# Altium schematic sheet MCU.SchDoc(MCU)
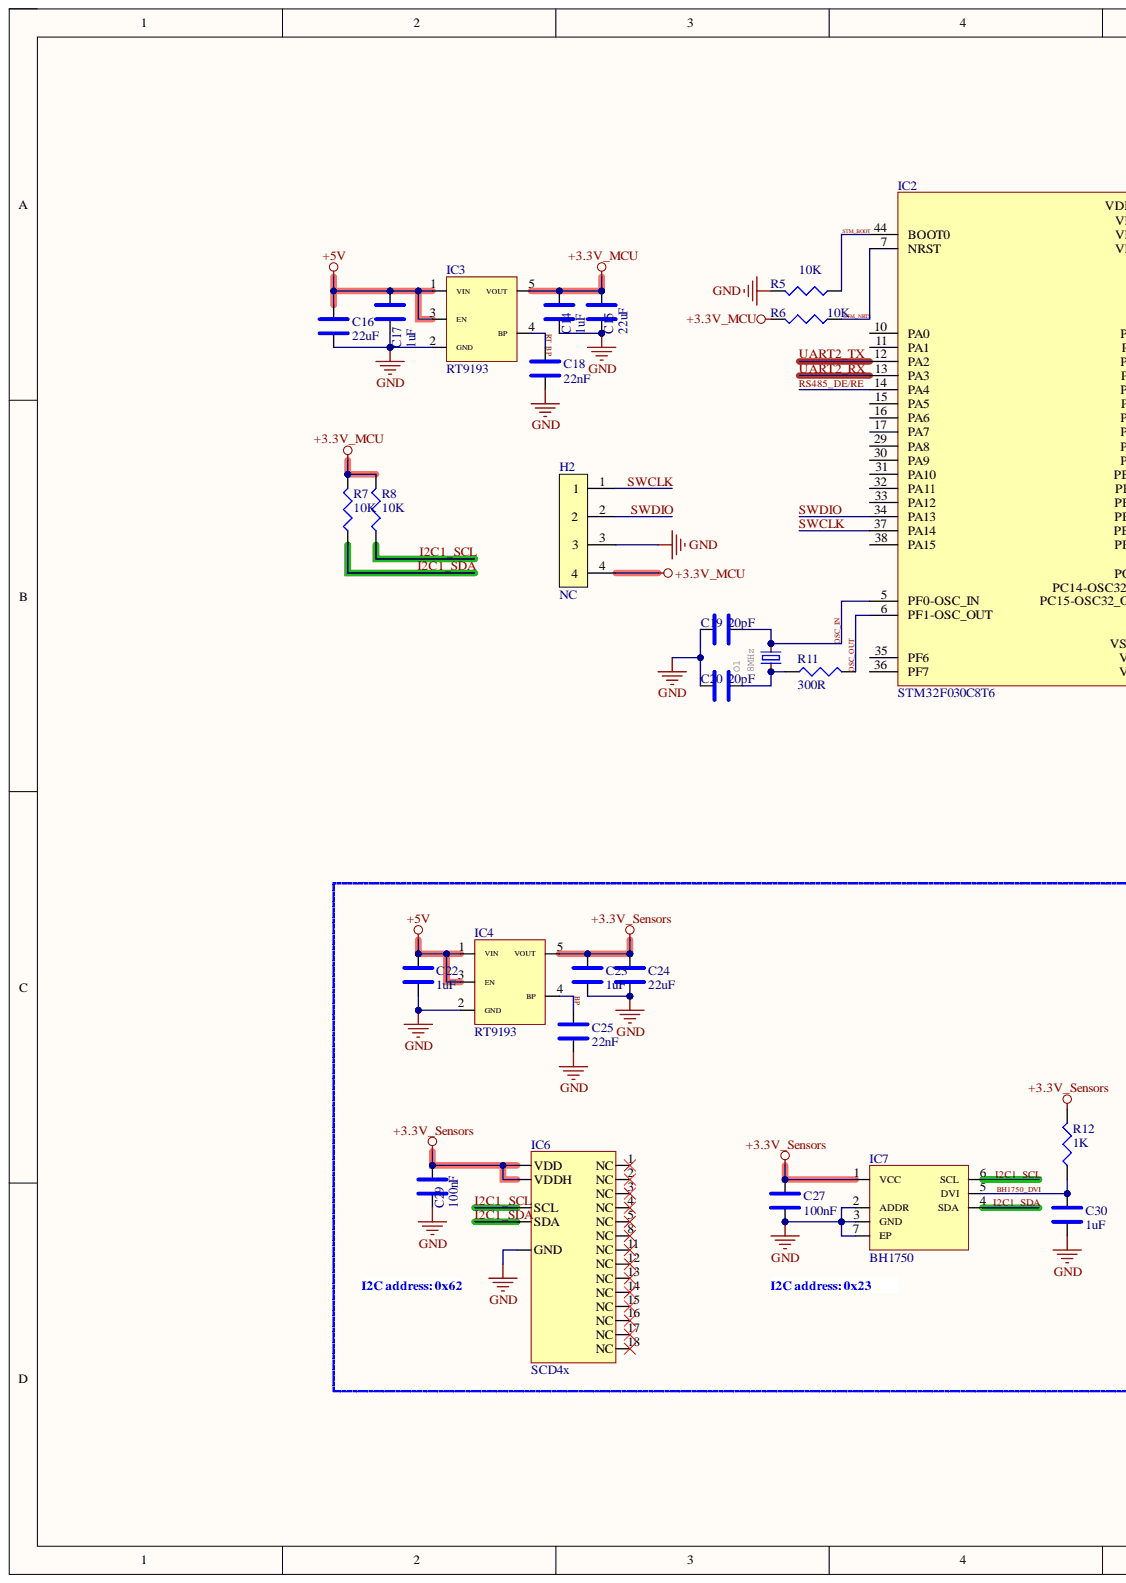
[diagram p0: MCU.SchDoc, left]
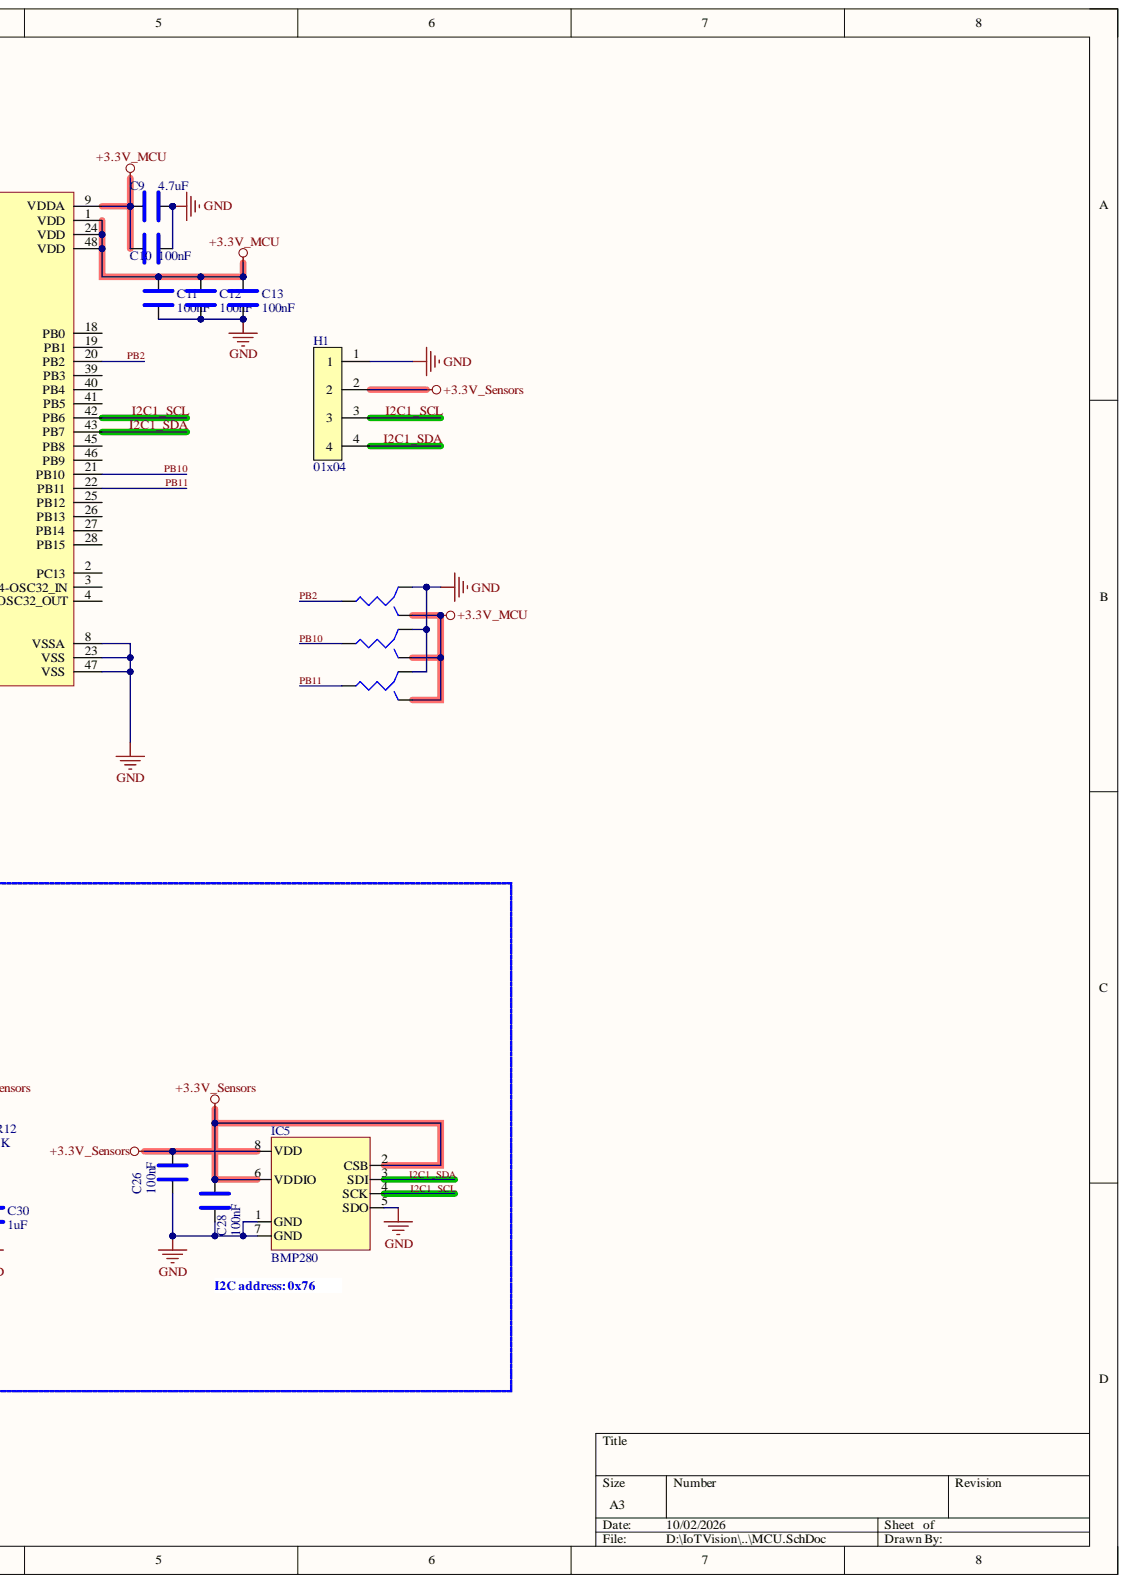
[diagram p1: MCU.SchDoc, right]

## Parts
C9  4.7uF  [C_0603]
C10  100nF  [C_0402]
C11  100nF  [C_0402]
C12  100nF  [C_0402]
C13  100nF  [C_0402]
C14  1uF  [C_0603]
C15  22uF  [C_0603]
C16  22uF  [C_0603]
C17  1uF  [C_0603]
C18  22nF  [C_0603]
C19  20pF  [C_0402]
C20  20pF  [C_0402]
C22  1uF  [C_0603]
C23  1uF  [C_0603]
C24  22uF  [C_0603]
C25  22nF  [C_0603]
C26  100nF  [C_0402]
C27  100nF  [C_0402]
C28  100nF  [C_0402]
C29  100nF  [C_0402]
C30  1uF  [C_0603]
H1  01x04  [MALEPIN_1x4]
H2  NC  [MALEPIN_1x4]
IC2  STM32F030C8T6  [LQFP48]
IC3  RT9193  [SOT-23-5]
IC4  RT9193  [SOT-23-5]
IC5  BMP280  [BMP280]
IC6  SCD4x  [SCD4x]
IC7  BH1750  [BH1750]
O1  8MHz  [CRYSTAL_5032]
R5  10K  [R_0402]
R6  10K  [R_0402]
R7  10K  [R_0402]
R8  10K  [R_0402]
R10  R  [R_0402_3Pad]  (value not drawn)
R11  300R  [R_0603]
R12  1K  [R_0603]
R18  R  [R_0402_3Pad]  (value not drawn)
R19  R  [R_0402_3Pad]  (value not drawn)

## Nets
+3.3V_MCU: C9.2 C10.2 C11.1 C12.1 C13.1 C14.2 C15.2 H2.4 IC2.1(VDD) IC2.9(VDDA) IC2.24(VDD) IC2.48(VDD) IC3.5(VOUT) R6.2 R7.2 R8.2 R10.3 R18.3 R19.3
+3.3V_Sensors: C23.2 C24.2 C26.1 C27.1 C28.1 C29.1 H1.2 IC4.5(VOUT) IC5.2(CSB) IC5.6(VDDIO) IC5.8(VDD) IC6.7(VDD) IC6.19(VDDH) IC7.1(VCC) R12.2
+5V: C16.2 C17.2 C22.2 IC3.1(VIN) IC3.3(EN) IC4.1(VIN) IC4.3(EN)
GND: C9.1 C10.1 C11.2 C12.2 C13.2 C14.1 C15.1 C16.1 C17.1 C18.1 C19.2 C20.2 C22.1 C23.1 C24.1 C25.1 C26.2 C27.2 C28.2 C29.2 C30.1 H1.1 H2.3 IC2.8(VSSA) IC2.23(VSS) IC2.47(VSS) IC3.2(GND) IC4.2(GND) IC5.1(GND) IC5.5(SDO) IC5.7(GND) IC6.6,20,21(GND) IC7.2(ADDR) IC7.3(GND) IC7.7(EP) R5.2 R10.2 R18.2 R19.2
BH1750_DVI: C30.2 IC7.5(DVI) R12.1
BP: C25.2 IC4.4(BP)
I2C1_SCL: H1.3 IC2.42(PB6) IC5.4(SCK) IC6.9(SCL) IC7.6(SCL) R8.1
I2C1_SDA: H1.4 IC2.43(PB7) IC5.3(SDI) IC6.10(SDA) IC7.4(SDA) R7.1
OSC_IN: C19.1 IC2.5(PF0-OSC_IN) O1.2
OSC_OUT: IC2.6(PF1-OSC_OUT) R11.2
PB2: IC2.20(PB2) R10.1
PB10: IC2.21(PB10) R18.1
PB11: IC2.22(PB11) R19.1
RS485_DE/RE: IC2.14(PA4)
RT_BP: C18.2 IC3.4(BP)
STM_BOOT: IC2.44(BOOT0) R5.1
STM_NRTS: IC2.7(NRST) R6.1
SWCLK: H2.1 IC2.37(PA14)
SWDIO: H2.2 IC2.34(PA13)
UART2_RX: IC2.13(PA3)
UART2_TX: IC2.12(PA2)
NetC20_1: C20.1 O1.1 R11.1

Not connected: IC2 2(PC13) 3(PC14-OSC32_IN) 4(PC15-OSC32_OUT) 10(PA0) 11(PA1) 15(PA5) 16(PA6) 17(PA7) 18(PB0) 19(PB1) 25(PB12) 26(PB13) 27(PB14) 28(PB15) 29(PA8) 30(PA9) 31(PA10) 32(PA11) 33(PA12) 35(PF6) 36(PF7) 38(PA15) 39(PB3) 40(PB4) 41(PB5) 45(PB8) 46(PB9); IC6 1(NC) 2(NC) 3(NC) 4(NC) 5(NC) 8(NC) 11(NC) 12(NC) 13(NC) 14(NC) 15(NC) 16(NC) 17(NC) 18(NC)

## Library data of the parts
C9-C20 C22-C30 = C: Component Kind: Standard; Description: C; Library Name: LNDB_Schlib.SchLib
H1 H2 = MalePin_1x4: Component Kind: Standard; Library Name: UY_MalePin2.54.SchLib
IC5 = BMP280: Pressure Sensor 4.35PSI ~ 15.95PSI (30kPa ~ 110kPa) Absolute 16b 8-SMD; Component Kind: Standard; Library Name: LNDB_Schlib.SchLib
IC7 = BH1750: SENSOR OPT 560NM AMBIENT 6WSOF; Component Kind: Standard; Library Name: LNDB_Schlib.SchLib
O1 = CRYSTAL_5032: DeviceName: -9B; GateName_1: G$1; SymbolName_1: Q; Component Kind: Standard; DeviceSetName: CRYSTAL_5032; LibraryName: Uy_SMD; Package: 5.0X3.2_2; Library Name: UY_Schlib.SchLib
R5-R8 R11 R12 = R: Component Kind: Standard; Description: R; Library Name: LNDB_Schlib.SchLib
R10 R18 R19 = R_3Pad: Component Kind: Standard; Description: R; Library Name: LNDB_Schlib.SchLib
IC2 = STM32F030C8T6: Component Kind: Standard; Description: STM32F030C8T6; Library Name: LNDB_Schlib.SchLib
IC3 IC4 = RT9193: Component Kind: Standard; Description: RT9193; Library Name: LNDB_Schlib.SchLib
IC6 = SCD4x: Component Kind: Standard; Description: SCD4x; Library Name: LNDB_Schlib.SchLib
